# Altium schematic sheet SIB CANBus.SchDoc
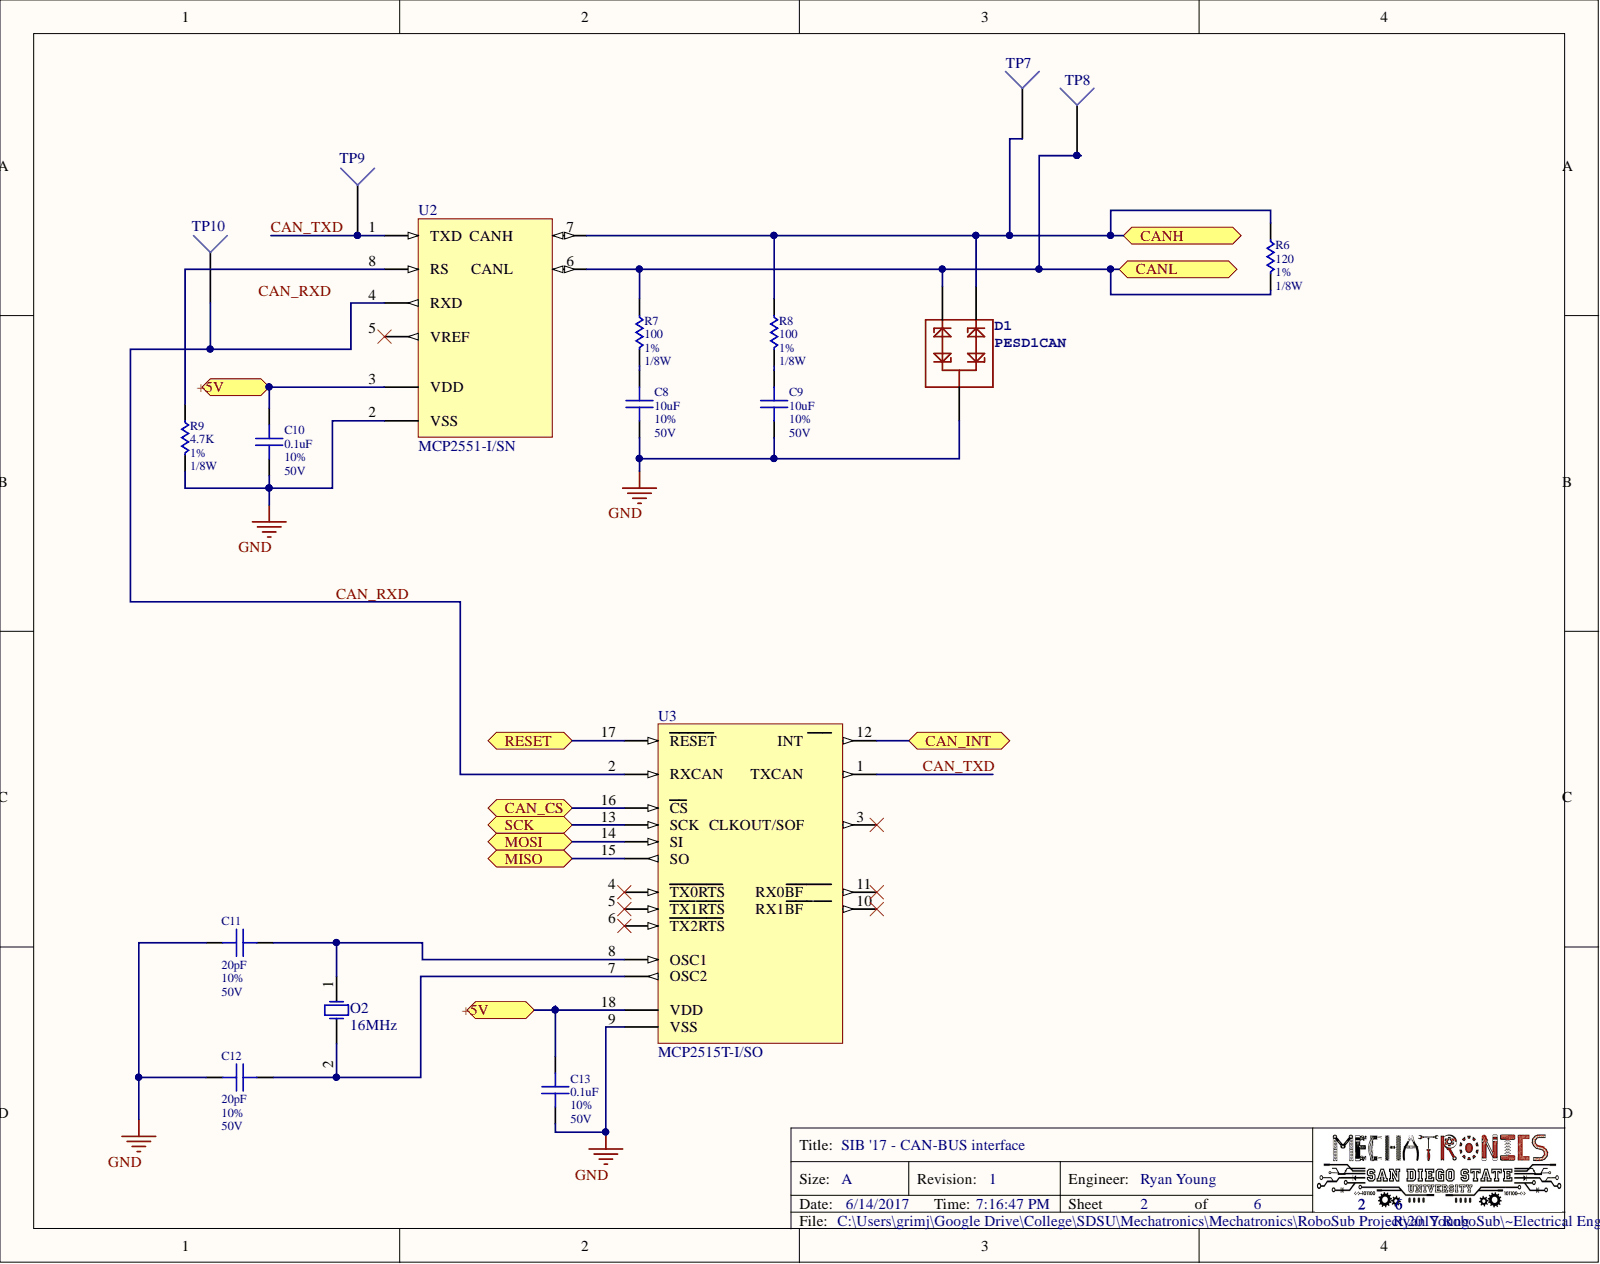
[diagram p0: SIB CANBus.SchDoc]

## Parts
C8  10uF  Tolerance: 10%  Voltage: 50V  [Component 0805]
C9  10uF  Tolerance: 10%  Voltage: 50V  [Component 0805]
C10  0.1uF  Tolerance: 10%  Voltage: 50V  [Component 0805]
C11  20pF  Tolerance: 10%  [Component 0805]
C12  20pF  Tolerance: 10%  [Component 0805]
C13  0.1uF  Tolerance: 10%  Voltage: 50V  [Component 0805]
D1  PESD1CAN  [SOT23_L]
O2  freq: 16MHz  [HC/49US]  (hidden comment: Crystal Oscillator)
R6  120  Power: 1/8W  Tolerance: 1%  [Component 0805]
R7  100  Power: 1/8W  Tolerance: 1%  [Component 0805]
R8  100  Power: 1/8W  Tolerance: 1%  [Component 0805]
R9  4.7K  Power: 1/8W  Tolerance: 1%  [Component 0805]
TP7  Test Point  [Test Point]  (value not drawn)
TP8  Test Point  [Test Point]  (value not drawn)
TP9  Test Point  [Test Point]  (value not drawn)
TP10  Test Point  [Test Point]  (value not drawn)
U2  MCP2551-I/SN  [SOIC-SN8_N]
U3  MCP2515T-I/SO  [SOIC-SO18_N]

## Ports
+5V, CAN_CS, CAN_INT, CANH, CANL, MISO, MOSI, RESET, SCK

## Nets
GND: C8.2 C9.2 C10.2 C11.1 C12.1 C13.2 D1.3 R9.2 U2.2(VSS) U3.9(VSS)
+5V: C10.1 C13.1 U2.3(VDD) U3.18(VDD) ; port +5V
CAN_CS: U3.16(CS) ; port CAN_CS
CAN_INT: U3.12 ; port CAN_INT
CAN_RXD: TP10.1 U2.4(RXD) U3.2(RXCAN)
CAN_TXD: TP9.1 U2.1(TXD) U3.1
CANH: D1.1 R6.1 R8.1 TP7.1 U2.7 ; port CANH
CANL: D1.2 R6.2 R7.1 TP8.1 U2.6 ; port CANL
MISO: U3.15(SO) ; port MISO
MOSI: U3.14(SI) ; port MOSI
RESET: U3.17(RESET) ; port RESET
SCK: U3.13(SCK) ; port SCK
NetC8_1: C8.1 R7.2
NetC9_1: C9.1 R8.2
NetC11_2: C11.2 O2.1 U3.8(OSC1)
NetC12_2: C12.2 O2.2 U3.7(OSC2)
NetR9_1: R9.1 U2.8(RS)

Not connected: U2 5(VREF); U3 3 4(TX0RTS) 5(TX1RTS) 6(TX2RTS) 10 11

## Library data of the parts
C8-C10 C13 = Capacitor, Ceramic: Component Kind: Standard; Library Name: MechatronicsSCH.SchLib
  C8 C9: Capacitance: 10uF
  C10 C13: Capacitance: 0.1uF
C11 C12 = Capacitor, Ceramic: Voltage: 50V; Capacitance: 20pF; Component Kind: Standard; Library Name: MechatronicsSCH.SchLib
D1 = PESD1CAN: PESD1CAN is used to protect two automotive CAN bus lines from ESD.; PROD_ID: DIO-12501; Component Kind: Standard; Library Name: SparkFun-DiscreteSemi.IntLib
R6-R9 = Resistor: Thick Film Resistors - SMD 1/16watt 1%; Component Kind: Standard; Library Name: MechatronicsSCH.SchLib
  R6: Resistance: 120
  R7 R8: Resistance: 100
  R9: Resistance: 4.7K
U2 = MCP2551-I/SN: High-Speed CAN Transceiver, 8-Pin SOIC, Industrial Temperature; Manufacturer: Microchip; Code_IPC: SOIC127P600-8; Code_JEDEC: MS-012; Component Kind: Standard; ComponentLink1Description: Manufacturer URL; ComponentLink1URL: http://www.microchip.com/; ComponentLink2Description: Datasheet; ComponentLink2URL: http://ww1.microchip.com/downloads/en/DeviceDoc/21667F.pdf; ComponentLink3Description: Package Specification; ComponentLink3URL: http://www.microchip.com/stellent/groups/techpub_sg/documents/packagingspec/en012702.pdf; DatasheetVersion: revF, Jul-2010; Library Name: Microchip Interface.IntLib; Mounting Technology: Surface Mount; PackageDescription: 8-Lead Plastic Small Outline (SN) - Narrow, 3.90mm Body [SOIC]; PackageReference: SOIC-SN8; PackageVersion: revBB, Aug-2009; PartNumber: MCP2551-I/SN; Supplier 1: Digi-Key; Supplier 2: Farnell; Supplier 3: Newark; Supplier 4: Mouser; Supplier Part Number 1: MCP2551-I/SN-ND; Supplier Part Number 2: 9758569; Supplier Part Number 3: 69K7604; Supplier Part Number 4: 579-MCP2551-I/SN
U3 = MCP2515T-I/SO: Stand-Alone CAN Controller With SPI Interface, 18-Pin SOIC, Industrial Temperature, Tape and Reel; Manufacturer: Microchip; Code_JEDEC: MS-013; Component Kind: Standard; ComponentLink1Description: Manufacturer URL; ComponentLink1URL: http://www.microchip.com/; ComponentLink2Description: Datasheet; ComponentLink2URL: http://ww1.microchip.com/downloads/en/DeviceDoc/21801f.pdf; ComponentLink3Description: Package Specification; ComponentLink3URL: http://www.microchip.com/stellent/groups/techpub_sg/documents/packagingspec/en012702.pdf; DatasheetVersion: revF, Oct-2010; Library Name: Microchip Interface.IntLib; Mounting Technology: Surface Mount; PackageDescription: 18-Lead Plastic Small Outline (SO) - Wide 7.50mm Body [SOIC]; PackageReference: SOIC-SO18; PackageVersion: revBB, Aug-2009; PartNumber: MCP2515T-I/SO; Supplier 1: Digi-Key; Supplier 2: Farnell; Supplier 3: Newark; Supplier 4: Mouser; Supplier Part Number 1: MCP2515T-I/SO-ND; Supplier Part Number 2: 1815922; Supplier Part Number 3: 29H7139; Supplier Part Number 4: 579-MCP2515T-I/SO
O2 = Crystal Oscillator: Component Kind: Standard; Library Name: MechatronicsSCH.SchLib
TP7-TP10 = Test Point: Component Kind: Standard; Library Name: RyanY-Integrated_Library.IntLib
